# Altium schematic sheet AD936X_RF.SchDoc
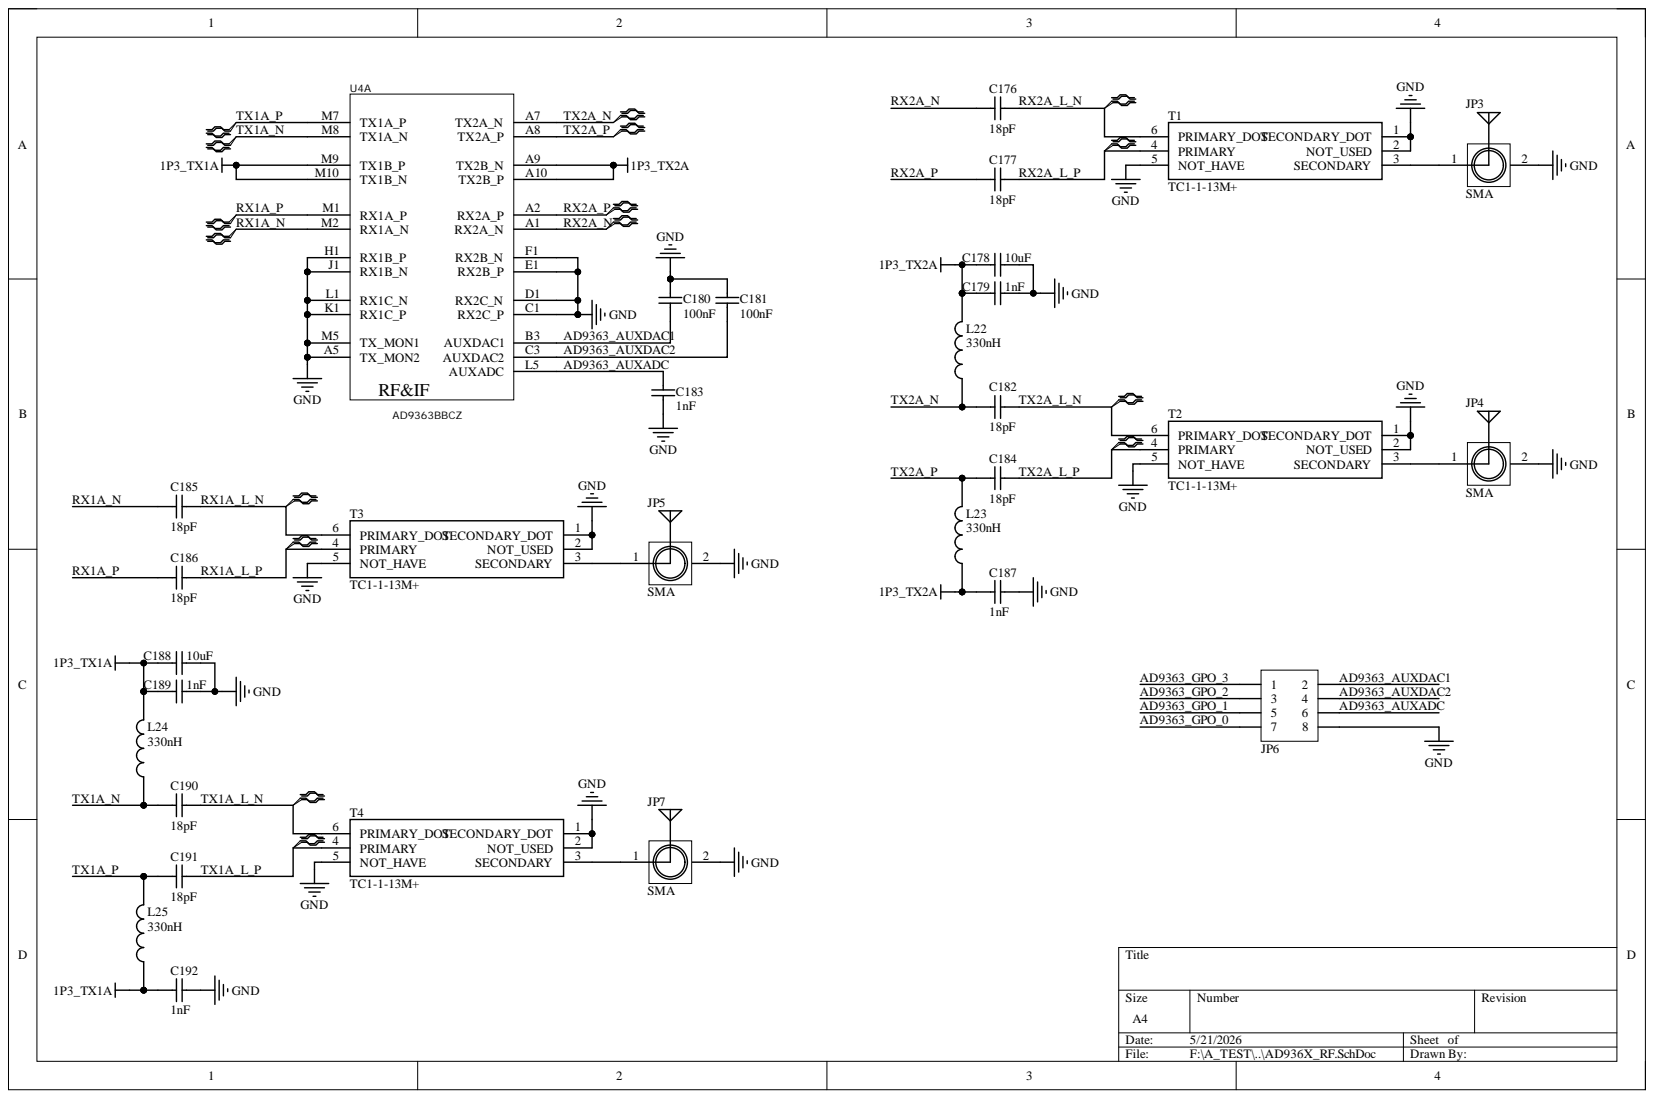
[diagram p0: AD936X_RF.SchDoc]

## Parts
C176  18pF  [C 0402_L]
C177  18pF  [C 0402_L]
C178  10uF  [C 0805_L]
C179  1nF  [C 0402_L]
C180  100nF  [C 0402_L]
C181  100nF  [C 0402_L]
C182  18pF  [C 0402_L]
C183  1nF  [C 0402_L]
C184  18pF  [C 0402_L]
C185  18pF  [C 0402_L]
C186  18pF  [C 0402_L]
C187  1nF  [C 0402_L]
C188  10uF  [C 0805_L]
C189  1nF  [C 0402_L]
C190  18pF  [C 0402_L]
C191  18pF  [C 0402_L]
C192  1nF  [C 0402_L]
JP3  SMA  [SMA-KE]
JP4  SMA  [SMA-KE]
JP5  SMA  [SMA-KE]
JP6  Header 4X2  [HDR2.54-LI-2x4P]  (value not drawn)
JP7  SMA  [SMA-KE]
L22  330nH  [L 0402]
L23  330nH  [L 0402]
L24  330nH  [L 0402]
L25  330nH  [L 0402]
T1  TC1-1-13M+  [TCM163AX2]
T2  TC1-1-13M+  [TCM163AX2]
T3  TC1-1-13M+  [TCM163AX2]
T4  TC1-1-13M+  [TCM163AX2]
U4  AD9363BBCZ  [BGA144C80P12X12_1000X1000X170]  (part A)

## Nets
1P3_TX1A: C188.1 C189.1 C192.2 L24.2 L25.1 U4.M9(TX1B_P) U4.M10(TX1B_N)
1P3_TX2A: C178.1 C179.1 C187.2 L22.2 L23.1 U4.A9(TX2B_N) U4.A10(TX2B_P)
GND: C178.2 C179.2 C180.2 C181.2 C183.1 C187.1 C188.2 C189.2 C192.1 JP3.2 JP4.2 JP5.2 JP6.8 JP7.2 T1.1(SECONDARY_DOT) T1.2(NOT_USED) T1.5(NOT_HAVE) T2.1(SECONDARY_DOT) T2.2(NOT_USED) T2.5(NOT_HAVE) T3.1(SECONDARY_DOT) T3.2(NOT_USED) T3.5(NOT_HAVE) T4.1(SECONDARY_DOT) T4.2(NOT_USED) T4.5(NOT_HAVE) U4.A5(TX_MON2) U4.C1(RX2C_P) U4.D1(RX2C_N) U4.E1(RX2B_P) U4.F1(RX2B_N) U4.H1(RX1B_P) U4.J1(RX1B_N) U4.K1(RX1C_P) U4.L1(RX1C_N) U4.M5(TX_MON1)
AD9363_AUXADC: C183.2 JP6.6 U4.L5(AUXADC)
AD9363_AUXDAC1: C180.1 JP6.2 U4.B3(AUXDAC1)
AD9363_AUXDAC2: C181.1 JP6.4 U4.C3(AUXDAC2)
AD9363_GPO_0: JP6.7
AD9363_GPO_1: JP6.5
AD9363_GPO_2: JP6.3
AD9363_GPO_3: JP6.1
RX1A_L_N: C185.2 T3.6(PRIMARY_DOT)
RX1A_L_P: C186.2 T3.4(PRIMARY)
RX1A_N: C185.1 U4.M2(RX1A_N)
RX1A_P: C186.1 U4.M1(RX1A_P)
RX2A_L_N: C176.2 T1.6(PRIMARY_DOT)
RX2A_L_P: C177.2 T1.4(PRIMARY)
RX2A_N: C176.1 U4.A1(RX2A_N)
RX2A_P: C177.1 U4.A2(RX2A_P)
TX1A_L_N: C190.2 T4.6(PRIMARY_DOT)
TX1A_L_P: C191.2 T4.4(PRIMARY)
TX1A_N: C190.1 L24.1 U4.M8(TX1A_N)
TX1A_P: C191.1 L25.2 U4.M7(TX1A_P)
TX2A_L_N: C182.2 T2.6(PRIMARY_DOT)
TX2A_L_P: C184.2 T2.4(PRIMARY)
TX2A_N: C182.1 L22.1 U4.A7(TX2A_N)
TX2A_P: C184.1 L23.2 U4.A8(TX2A_P)
NetJP3_1: JP3.1 T1.3(SECONDARY)
NetJP4_1: JP4.1 T2.3(SECONDARY)
NetJP5_1: JP5.1 T3.3(SECONDARY)
NetJP7_1: JP7.1 T4.3(SECONDARY)

## Library data of the parts
C176-C192 = Cap: 贴片电容; Component Kind: Standard; Library Name: A_Schlib.SchLib
  C176 C177 C182 C184-C186 C190 C191: value: 18pF
  C178 C188: value: 10uF
  C179 C183 C187 C189 C192: value: 1nF
  C180 C181: value: 100nF
JP3-JP5 JP7 = SMA: SMA-2.4G射频天线接口; 版权归属: 源创客; BOM名称: SMA-2.4G射频天线接口; Component Kind: Standard; Library Name: A_Schlib.SchLib; 学习资源: www.ooocke.com
JP6 = Header 4X2: 4*2P接插件; 版权归属: 封装库来自淘宝 ID：黄晶宝石; BOM名称: 4*2P接插件; Component Kind: Standard; 学习资源: https://shop463601444.taobao.com; Library Name: 2.54间距排针排母插件贴片.SchLib
L22-L25 = 电感: 包含功率; Component Kind: Standard; Library Name: A_Schlib.SchLib
T1-T4 = TC1-1-13M+: Transformer; Manufacturer_Name: Mini-Circuits; Component Kind: Standard; Datasheet Link: https://datasheet.datasheetarchive.com/originals/distributors/Datasheets-28/DSA-546322.pdf; Height: 4.06mm; Library Name: SamacSys.SchLib; Manufacturer_Part_Number: TC1-1-13M+; Mouser Part Number: 139-TC1-1-13M; Mouser Price/Stock: https://www.mouser.co.uk/ProductDetail/Mini-Circuits/TC1-1-13M%2b?qs=xZ%2FP%252Ba9zWqYek%252BZGAF2fWg%3D%3D
U4 = AD936X: Component Kind: Standard; Library Name: A_Schlib.SchLib
